# Altium schematic sheet board_sheet1.SchDoc
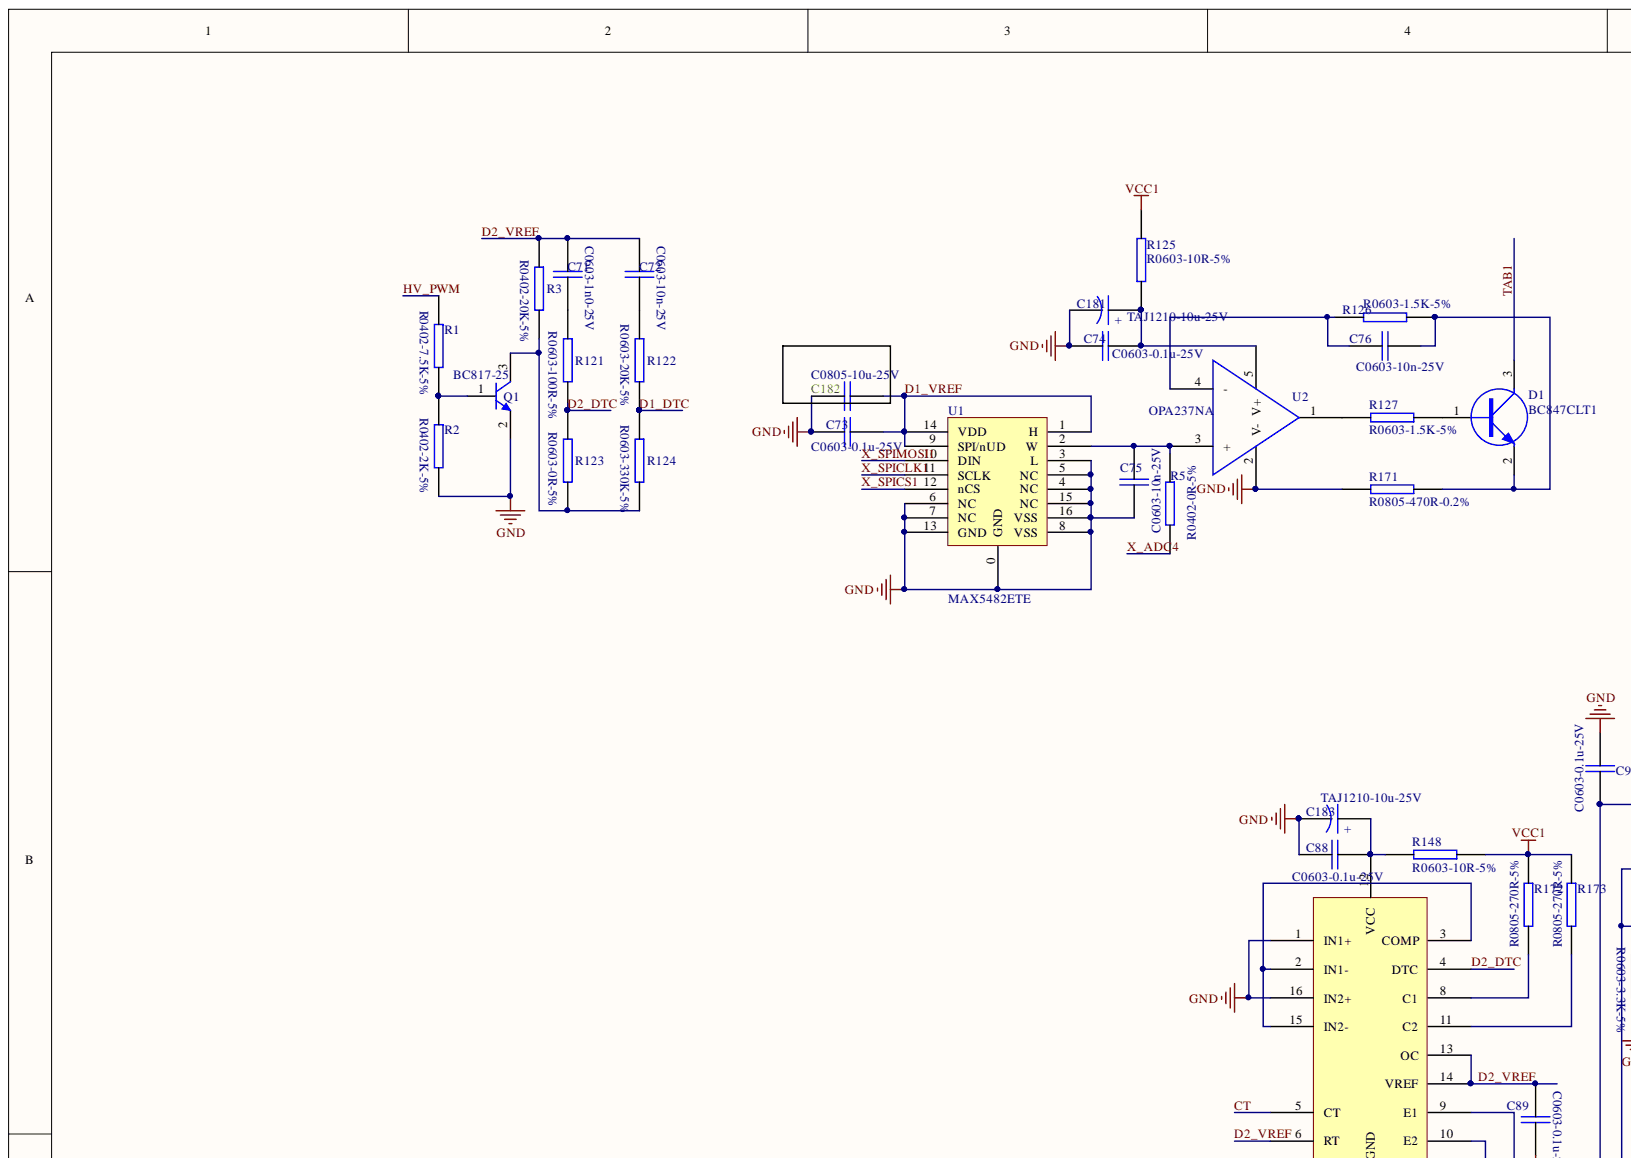
[diagram p0: board_sheet1.SchDoc, top left]
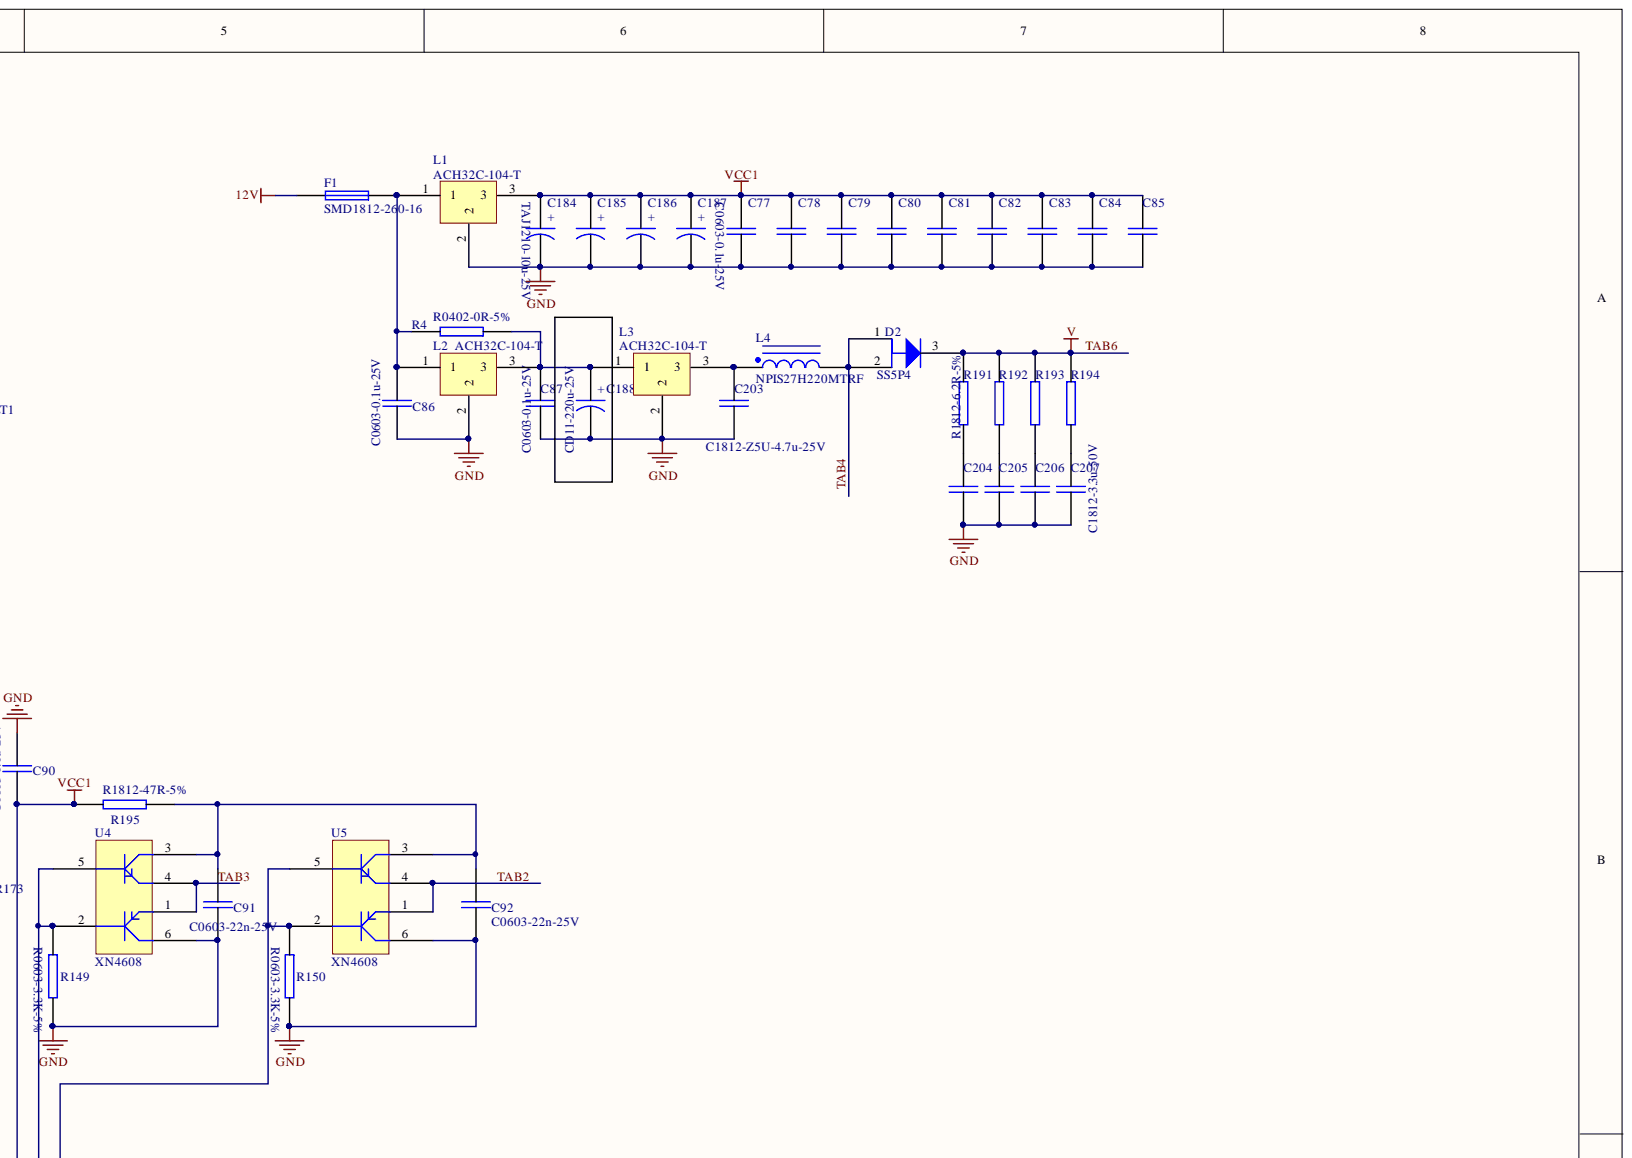
[diagram p1: board_sheet1.SchDoc, top right]
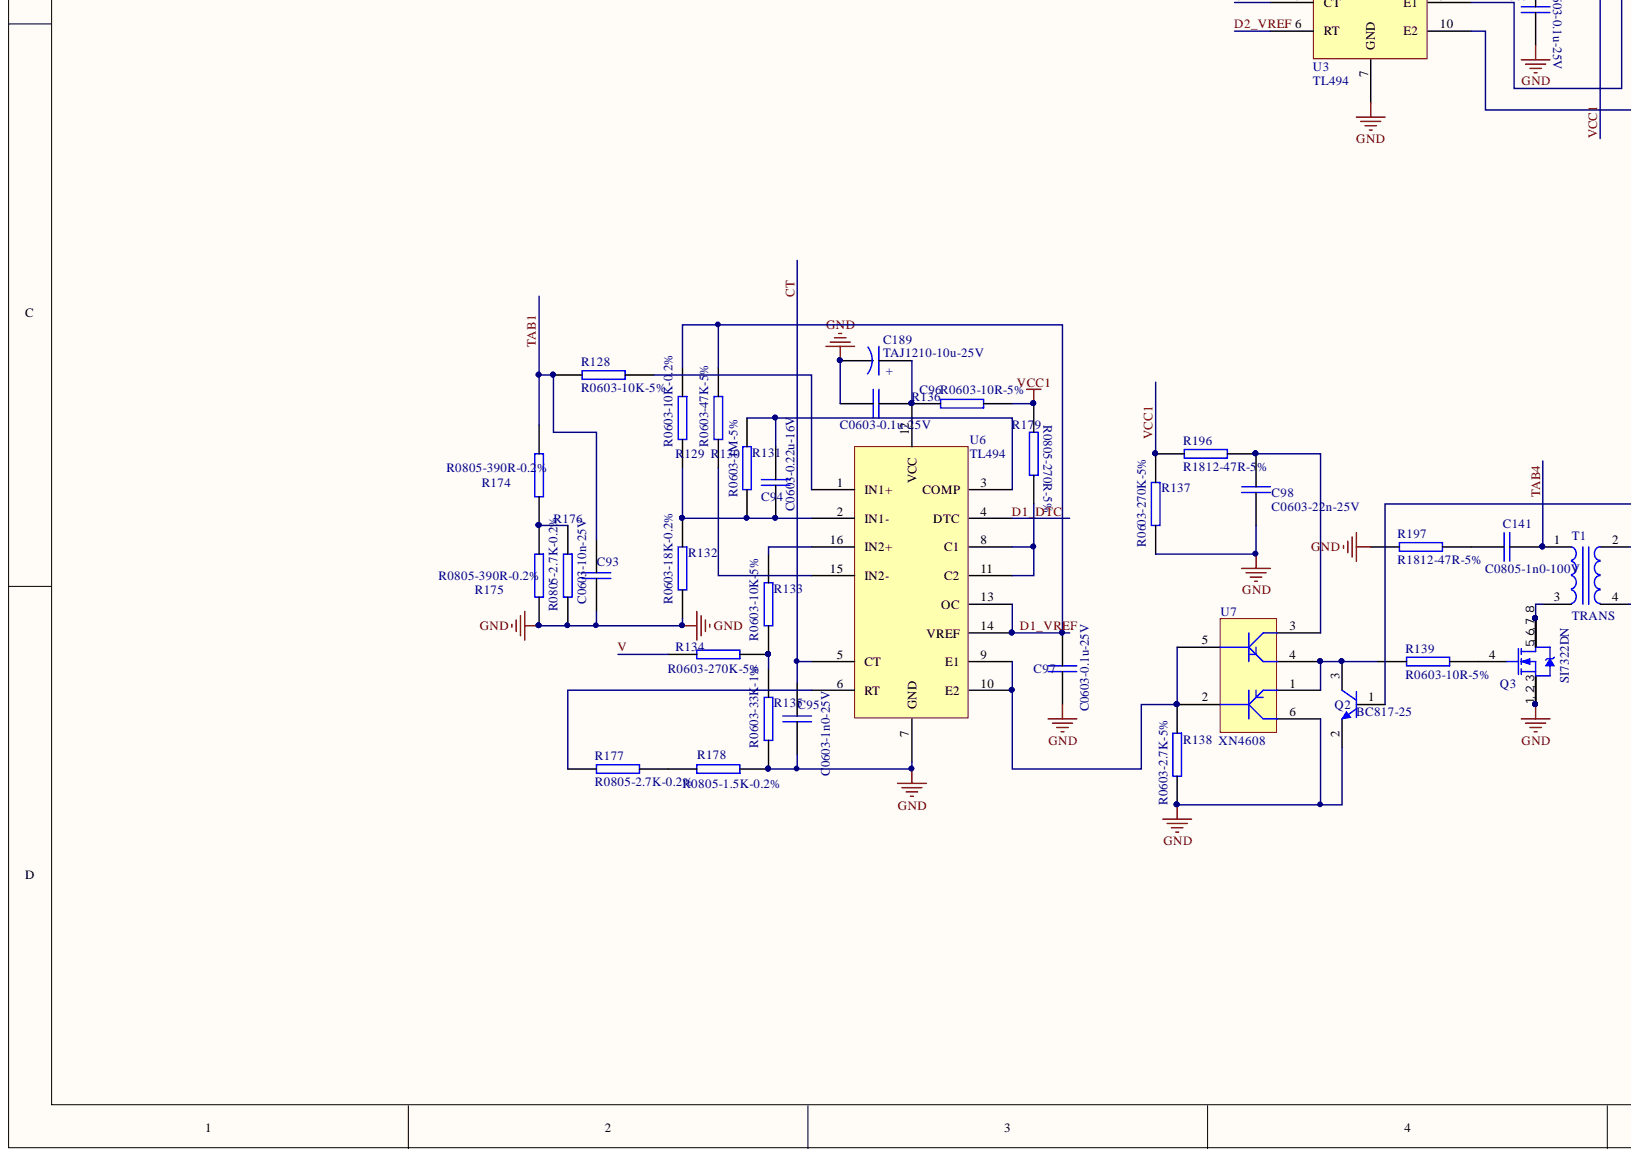
[diagram p2: board_sheet1.SchDoc, bottom left]
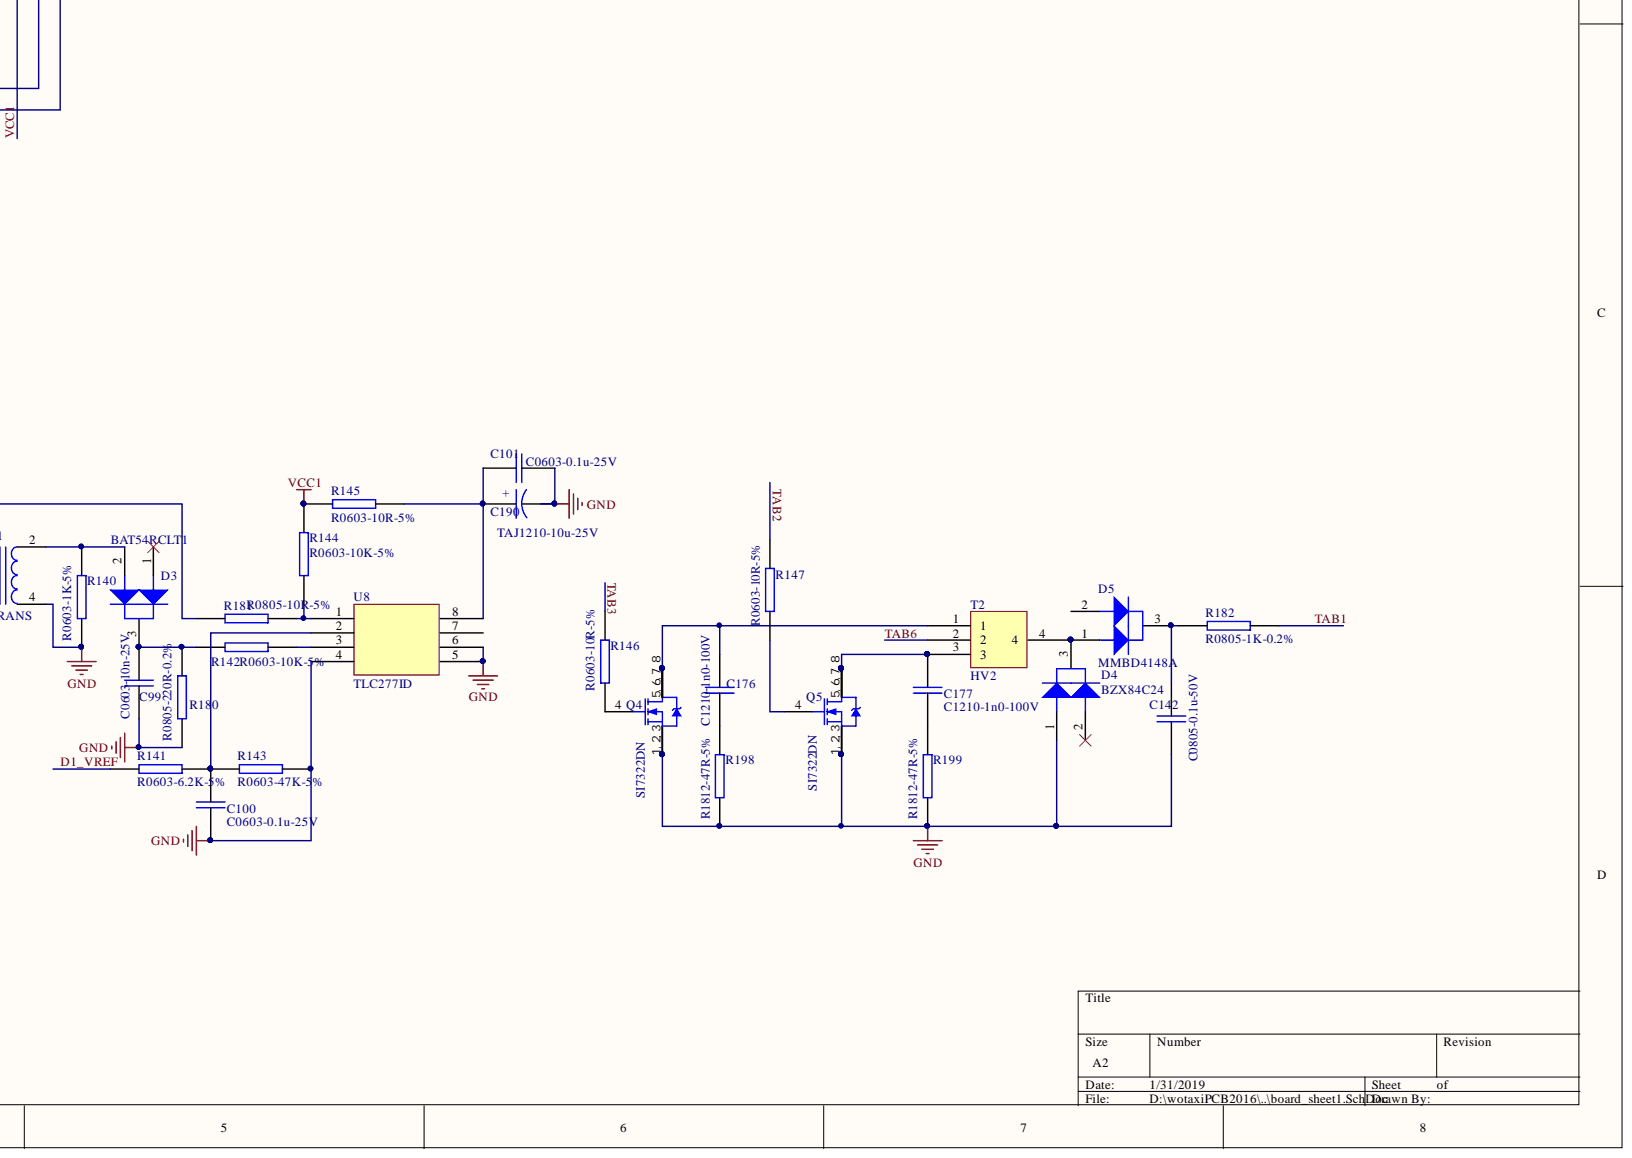
[diagram p3: board_sheet1.SchDoc, bottom right]

## Parts
C71  C0603-1n0-25V  [0603]
C72  C0603-10n-25V  [0603]
C73  C0603-0.1u-25V  [0603]
C74  C0603-0.1u-25V  [0603]
C75  C0603-10n-25V  [0603]
C76  C0603-10n-25V  [0603]
C77  C0603-0.1u-25V  [0603]
C78  C0603-0.1u-25V  [0603]
C79  C0603-0.1u-25V  [0603]
C80  C0603-0.1u-25V  [0603]
C81  C0603-0.1u-25V  [0603]
C82  C0603-0.1u-25V  [0603]
C83  C0603-0.1u-25V  [0603]
C84  C0603-0.1u-25V  [0603]
C85  C0603-0.1u-25V  [0603]
C86  C0603-0.1u-25V  [0603]
C87  C0603-0.1u-25V  [0603]
C88  C0603-0.1u-25V  [0603]
C89  C0603-0.1u-25V  [0603]
C90  C0603-0.1u-25V  [0603]
C91  C0603-22n-25V  [0603]
C92  C0603-22n-25V  [0603]
C93  C0603-10n-25V  [0603]
C94  C0603-0.22u-16V  [0603]
C95  C0603-1n0-25V  [0603]
C96  C0603-0.1u-25V  [0603]
C97  C0603-0.1u-25V  [0603]
C98  C0603-22n-25V  [0603]
C99  C0603-10n-25V  [0603]
C100  C0603-0.1u-25V  [0603]
C101  C0603-0.1u-25V  [0603]
C141  C0805-1n0-100V  [0805]
C142  C0805-0.1u-50V  [0805]
C176  C1210-1n0-100V  [1210]
C177  C1210-1n0-100V  [1210]
C181  TAJ1210-10u-25V  [1210]
C182  C0805-10u-25V  [0805]
C183  TAJ1210-10u-25V  [1210]
C184  TAJ1210-10u-25V  [1210]
C185  TAJ1210-10u-25V  [1210]
C186  TAJ1210-10u-25V  [1210]
C187  TAJ1210-10u-25V  [1210]
C188  CD11-220u-25V  [CD11]
C189  TAJ1210-10u-25V  [1210]
C190  TAJ1210-10u-25V  [1210]
C203  C1812-Z5U-4.7u-25V  [1812]
C204  C1812-3.3u-50V  [1812]
C205  C1812-3.3u-50V  [1812]
C206  C1812-3.3u-50V  [1812]
C207  C1812-3.3u-50V  [1812]
D1  BC847CLT1  [SO-G3/C2.5]
D2  SS5P4  [SS5P4]
D3  BAT54RCLT1  [SO-G3/C2.5]
D4  BZX84C24  [SO-G3/C2.5]
D5  MMBD4148A  [SO-G3/C2.5]
F1  SMD1812-260-16  [C4532-1812]
L1  ACH32C-104-T  [ACH32C]
L2  ACH32C-104-T  [ACH32C]
L3  ACH32C-104-T  [ACH32C]
L4  NPIS27H220MTRF  [LNPIS27H220MTRF]
Q1  BC817-25  [SO-G3/C2.5]
Q2  BC817-25  [SO-G3/C2.5]
Q3  SI7322DN  [PowerPAK1212-8S]
Q4  SI7322DN  [PowerPAK1212-8S]
Q5  SI7322DN  [PowerPAK1212-8S]
R1  R0402-7.5K-5%  [0402]
R2  R0402-2K-5%  [0402]
R3  R0402-20K-5%  [0402]
R4  R0402-0R-5%  [0402]
R5  R0402-0R-5%  [0402]
R121  R0603-100R-5%  [0603]
R122  R0603-20K-5%  [0603]
R123  R0603-0R-5%  [0603]
R124  R0603-330K-5%  [0603]
R125  R0603-10R-5%  [0603]
R126  R0603-1.5K-5%  [0603]
R127  R0603-1.5K-5%  [0603]
R128  R0603-10K-5%  [0603]
R129  R0603-10K-0.2%  [0603]
R130  R0603-47K-5%  [0603]
R131  R0603-1M-5%  [0603]
R132  R0603-18K-0.2%  [0603]
R133  R0603-10K-5%  [0603]
R134  R0603-270K-5%  [0603]
R135  R0603-33K-1%  [0603]
R136  R0603-10R-5%  [0603]
R137  R0603-270K-5%  [0603]
R138  R0603-2.7K-5%  [0603]
R139  R0603-10R-5%  [0603]
R140  R0603-1K-5%  [0603]
R141  R0603-6.2K-5%  [0603]
R142  R0603-10K-5%  [0603]
R143  R0603-47K-5%  [0603]
R144  R0603-10K-5%  [0603]
R145  R0603-10R-5%  [0603]
R146  R0603-10R-5%  [0603]
R147  R0603-10R-5%  [0603]
R148  R0603-10R-5%  [0603]
R149  R0603-3.3K-5%  [0603]
R150  R0603-3.3K-5%  [0603]
R171  R0805-470R-0.2%  [0805]
R172  R0805-270R-5%  [0805]
R173  R0805-270R-5%  [0805]
R174  R0805-390R-0.2%  [0805]
R175  R0805-390R-0.2%  [0805]
R176  R0805-2.7K-0.2%  [0805]
R177  R0805-2.7K-0.2%  [0805]
R178  R0805-1.5K-0.2%  [0805]
R179  R0805-270R-5%  [0805]
R180  R0805-220R-0.2%  [0805]
R181  R0805-10R-5%  [0603]
R182  R0805-1K-0.2%  [0805]
R191  R1812-6.2R-5%  [1812]
R192  R1812-6.2R-5%  [1812]
R193  R1812-6.2R-5%  [1812]
R194  R1812-6.2R-5%  [1812]
R195  R1812-47R-5%  [1812]
R196  R1812-47R-5%  [1812]
R197  R1812-47R-5%  [1812]
R198  R1812-47R-5%  [1812]
R199  R1812-47R-5%  [1812]
T1  TRANS  [TRANS1]
T2  HV2  [HV]
U1  MAX5482ETE  [QFN16]
U2  OPA237NA  [SO-G5/P.95]
U3  TL494  [SO-G16]
U4  XN4608  [SOT23-6_N]
U5  XN4608  [SOT23-6_N]
U6  TL494  [SO-G16]
U7  XN4608  [SOT26]
U8  TLC277ID  [SO-G8/Y2.2]

## Nets
12V: F1.1
GND: C73.1 C74.1 C75.2 C77.2 C78.2 C79.2 C80.2 C81.2 C82.2 C83.2 C84.2 C85.2 C86.2 C87.2 C88.1 C89.2 C90.1 C91.2 C92.1 C93.2 C95.2 C96.1 C97.2 C98.1 C99.2 C100.2 C101.2 C142.1 C181.2 C182.1 C183.2 C184.2 C185.2 C186.2 C187.2 C188.2 C189.2 C190.2 C203.2 C204.2 C205.2 C206.2 C207.2 D4.1 L1.2 L2.2 L3.2 Q1.2 Q2.2 Q3.1 Q3.2 Q3.3 Q4.1 Q4.2 Q4.3 Q5.1 Q5.2 Q5.3 R2.1 R132.1 R135.2 R137.1 R138.1 R140.1 R143.2 R149.1 R150.1 R171.1 R175.1 R176.1 R178.2 R180.1 R197.1 R198.1 R199.1 T1.4 U1.0(GND) U1.3(L) U1.4(NC) U1.5(NC) U1.6(NC) U1.7(NC) U1.8(VSS) U1.13(GND) U1.15(NC) U1.16(VSS) U2.2(V-) U3.1(IN1+) U3.7(GND) U3.16(IN2+) U4.6 U5.6 U6.7(GND) U7.6 U8.4 U8.5 U8.6
CT: C95.1 U3.5(CT) U6.5(CT)
D1_DTC: R122.1 R124.2 U6.4(DTC)
D1_VREF: C73.2 C97.1 C182.2 R129.2 R130.2 R141.1 U1.1(H) U1.9(SPI/nUD) U1.14(VDD) U6.13(OC) U6.14(VREF)
D2_DTC: R121.1 R123.1 U3.4(DTC)
D2_VREF: C71.1 C72.1 C89.1 R3.2 U3.6(RT) U3.13(OC) U3.14(VREF)
HV_PWM: R1.2
TAB1: C93.1 D1.3 R128.1 R174.2 R182.2
TAB2: R147.2 U5.1 U5.4
TAB3: R146.1 U4.1 U4.4
TAB4: C141.2 D2.1 D2.2 L4.2 T1.1
TAB6: D2.3 R134.1 R191.2 R192.2 R193.1 R194.2 T2.2
VCC1: C77.1 C78.1 C79.1 C80.1 C81.1 C82.1 C83.1 C84.1 C85.1 C90.2 C184.1 C185.1 C186.1 C187.1 L1.3 R125.1 R136.2 R137.2 R144.2 R145.1 R148.2 R172.2 R173.2 R179.2 R195.1 R196.1
X_ADC4: R5.1
X_SPICLK1: U1.11(SCLK)
X_SPICS1: U1.12(nCS)
X_SPIMOSI1: U1.10(DIN)
NetC71_2: C71.2 R121.2
NetC72_2: C72.2 R122.2
NetC74_2: C74.2 C181.1 R125.2 U2.5(V+)
NetC75_1: C75.1 R5.2 U1.2(W) U2.3(+)
NetC76_1: C76.1 R126.1 U2.4(-)
NetC76_2: C76.2 D1.2 R126.2 R171.2
NetC86_1: C86.1 F1.2 L1.1 L2.1 R4.1
NetC87_1: C87.1 C188.1 L2.3 L3.1 R4.2
NetC88_2: C88.2 C183.1 R148.1 U3.12(VCC)
NetC91_1: C91.1 C92.2 R195.2 U4.3 U5.3
NetC94_1: C94.1 R131.2 U6.3(COMP)
NetC94_2: C94.2 R129.1 R131.1 R132.2 U6.2(IN1-)
NetC96_2: C96.2 C189.1 R136.1 U6.12(VCC)
NetC98_2: C98.2 R196.2 U7.3
NetC99_1: C99.1 D3.3 R142.1 R180.2
NetC100_1: C100.1 R141.2 R143.1 U8.2
NetC101_1: C101.1 C190.1 R145.2 U8.8
NetC141_1: C141.1 R197.2
NetC142_2: C142.2 D5.3 R182.1
NetC176_1: C176.1 Q4.0 Q4.5 Q4.6 Q4.7 Q4.8 T2.1
NetC176_2: C176.2 R198.2
NetC177_1: C177.1 Q5.0 Q5.5 Q5.6 Q5.7 Q5.8 T2.3
NetC177_2: C177.2 R199.2
NetC203_1: C203.1 L3.3 L4.1
NetC204_1: C204.1 R191.1
NetC205_1: C205.1 R192.1
NetC206_1: C206.1 R193.2
NetC207_1: C207.1 R194.1
NetD1_1: D1.1 R127.2
NetD3_2: D3.2 R140.2 T1.2
NetD4_3: D4.3 D5.1 T2.4
NetQ1_1: Q1.1 R1.1 R2.2
NetQ1_3: Q1.3 R3.1 R123.2 R124.1
NetQ2_1: Q2.1 R181.1
NetQ2_3: Q2.3 R139.1 U7.1 U7.4
NetQ3_0: Q3.0 Q3.5 Q3.6 Q3.7 Q3.8 T1.3
NetQ3_4: Q3.4 R139.2
NetQ4_4: Q4.4 R146.2
NetQ5_4: Q5.4 R147.1
NetR127_1: R127.1 U2.1
NetR128_2: R128.2 U6.1(IN1+)
NetR130_1: R130.1 U6.15(IN2-)
NetR133_1: R133.1 R134.2 R135.1
NetR133_2: R133.2 U6.16(IN2+)
NetR138_2: R138.2 U6.9(E1) U6.10(E2) U7.2 U7.5
NetR142_2: R142.2 U8.3
NetR144_1: R144.1 R181.2 U8.1
NetR149_2: R149.2 U3.9(E1) U4.2 U4.5
NetR150_2: R150.2 U3.10(E2) U5.2 U5.5
NetR172_1: R172.1 U3.8(C1)
NetR173_1: R173.1 U3.11(C2)
NetR174_1: R174.1 R175.2 R176.2
NetR177_1: R177.1 U6.6(RT)
NetR177_2: R177.2 R178.1
NetR179_1: R179.1 U6.8(C1) U6.11(C2)
NetU3_2: U3.2(IN1-) U3.3(COMP) U3.15(IN2-)

Not connected: D3 1; D4 2; D5 2; U8 7

## Library data of the parts
C71-C101 C141 C142 C176 C177 C182 C203-C207 = CAP: Component Kind: Standard; Library Name: KL300-POWER.SCHLIB
C181 C183-C190 = CAP POL: Component Kind: Standard; Library Name: KL300-POWER.SCHLIB
D4 D5 = BAT54RCLT1: Component Kind: Standard; Library Name: KL300-POWER.SCHLIB
F1 = FUSE: Component Kind: Standard; Library Name: KL300-POWER.SCHLIB
L1-L3 = ACH32C: Component Kind: Standard; Library Name: KL300-POWER.SCHLIB
L4 = INDUCTOR POL: Component Kind: Standard; Library Name: KL300-POWER.SCHLIB
Q3-Q5 = IRF7201: Component Kind: Standard; Library Name: KL300-POWER.SCHLIB
R1-R5 R121-R150 R171-R182 R191-R199 = RES: Component Kind: Standard; Library Name: KL300-POWER.SCHLIB
D1 = BC847CLT1: Component Kind: Standard; Library Name: KL300-POWER.SCHLIB
D2 = SS5P4: Component Kind: Standard; Library Name: KL300-POWER.SCHLIB
D3 = BAT54RCLT1: Component Kind: Standard; Library Name: KL300-POWER.SCHLIB
Q1 Q2 = BC817-25: Component Kind: Standard; Library Name: KL300-POWER.SCHLIB
T1 = TRANS: Component Kind: Standard; Library Name: KL300-POWER.SCHLIB
T2 = HV2: Component Kind: Standard; Library Name: KL300-POWER.SCHLIB
U1 = MAX5482ETE: Component Kind: Standard; Library Name: KL300-POWER.SCHLIB
U2 = OPA237NA: Component Kind: Standard; Library Name: KL300-POWER.SCHLIB
U3 U6 = TL494: Component Kind: Standard; Library Name: KL300-POWER.SCHLIB
U4 U5 U7 = XN4608: Component Kind: Standard; Library Name: KL300-POWER.SCHLIB
U8 = TLC277ID: Component Kind: Standard; Library Name: KL300-POWER.SCHLIB
